# Altium schematic sheet pro.SchDoc
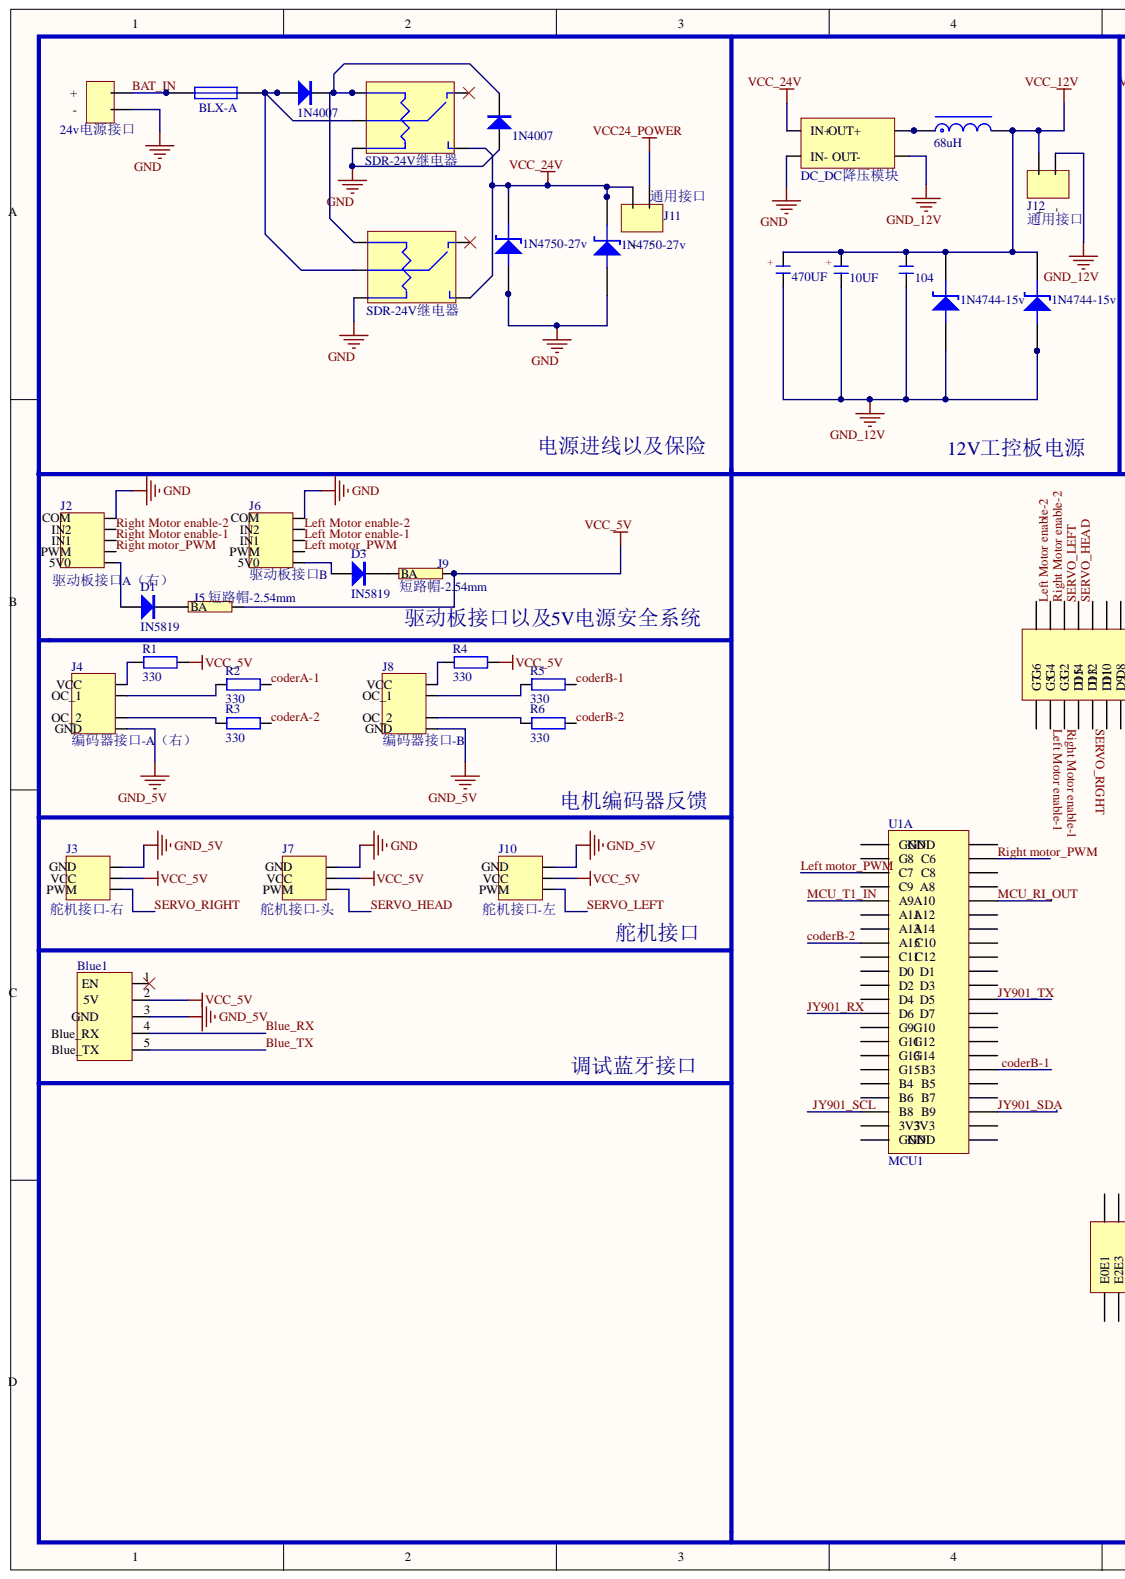
[diagram p0: pro.SchDoc, left]
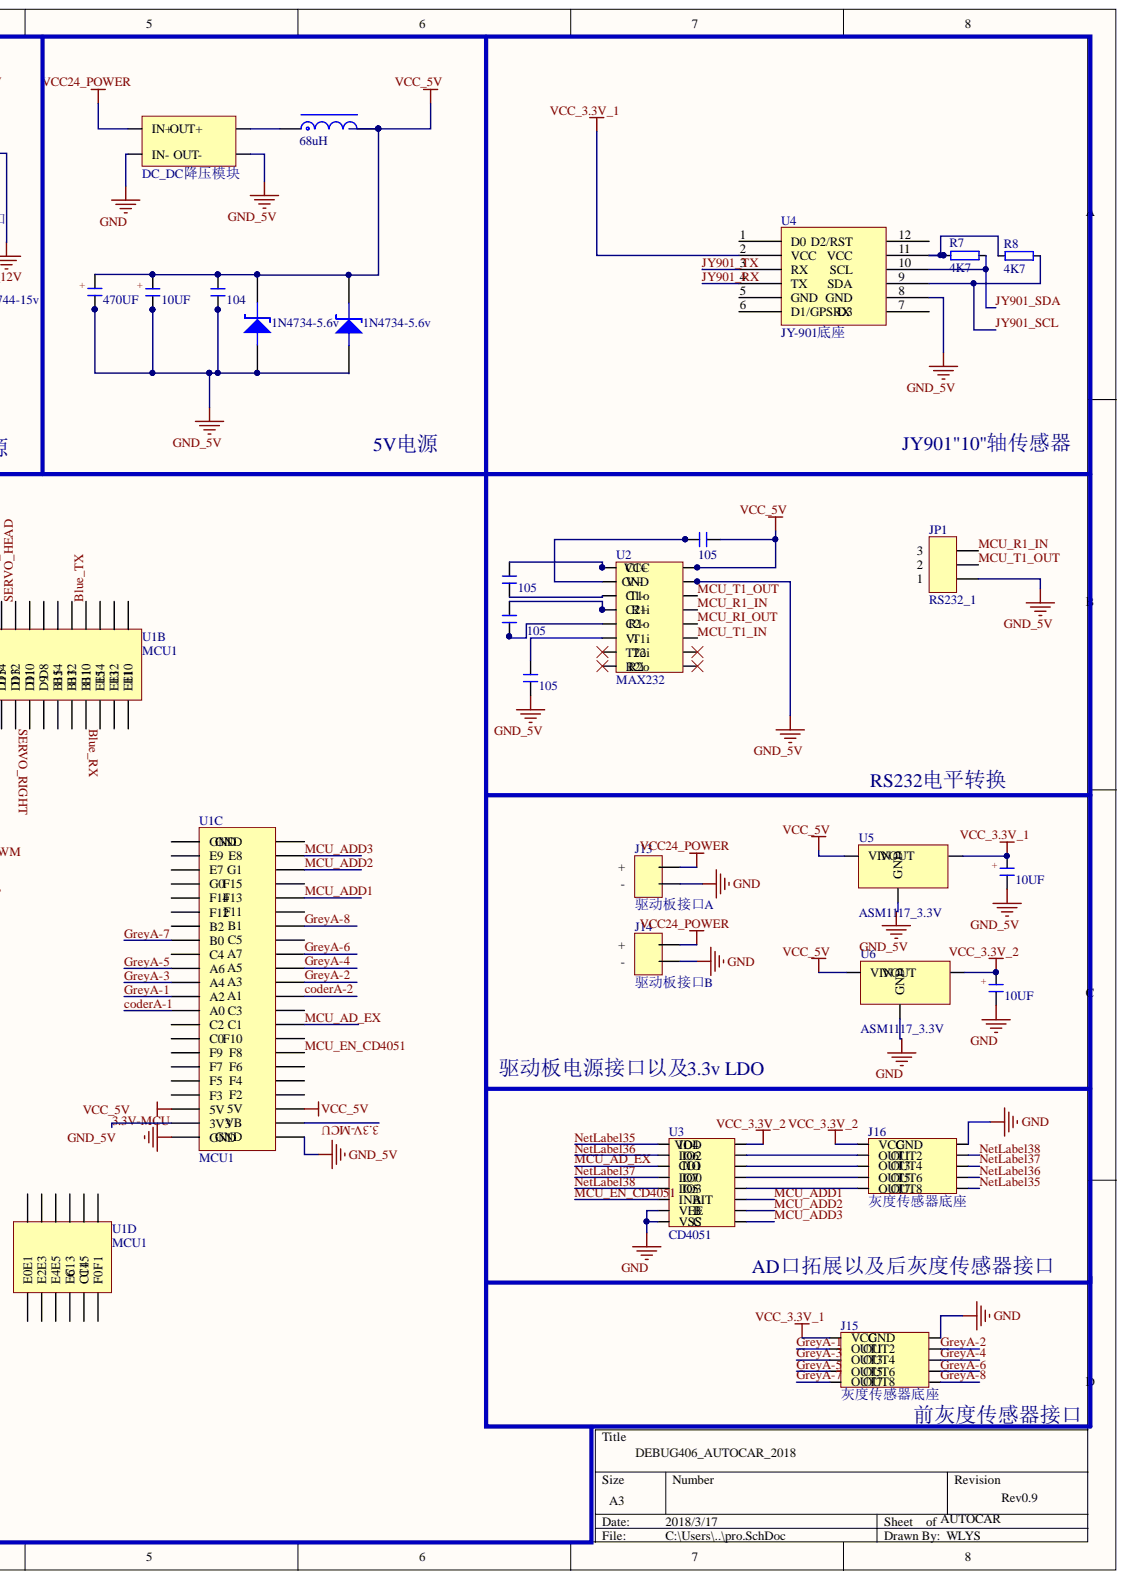
[diagram p1: pro.SchDoc, right]

## Parts
1  (no value)  [FU BLX-A]
Blue1  J？  [HDR2.54-LI-5P]  (value not drawn)
C1  470UF  [CAP10]
C2  10UF  [CAP6.3]
C3  104  [1206C]
C4  470UF  [CAP10]
C5  10UF  [CAP6.3]
C6  104  [1206C]
C7  105  [1206C]
C8  105  [1206C]
C9  105  [1206C]
C10  105  [1206C]
C11  10UF  [CAP6.3]
C12  10UF  [CAP6.3]
D1  IN5819  [DO-41]
D2  1N4007  [DO-41]
D3  IN5819  [DO-41]
D4  1N4007  [DO-41]
D5  1N4750-27v  [DO-41]
D6  1N4750-27v  [DO-41]
D7  1N4744-15v  [DO-41]
D8  1N4744-15v  [DO-41]
D9  1N4734-5.6v  [DO-41]
D10  1N4734-5.6v  [DO-41]
DC1  DC_DC降压模块  [DC_DC降压模块]
DC2  DC_DC降压模块  [DC_DC降压模块]
J1  (no value)  [autocar_battery]
J2  驱动板接口A（右）  [XH2.54-LI-5P]
J3  舵机接口-右  [XH2.54-LI-3P]
J4  编码器接口-A（右）  [XH2.54-LI-4P]
J5  短路帽-2.54mm  [HDR2.54-LI-2P]
J6  驱动板接口B  [XH2.54-LI-5P]
J7  舵机接口-头  [XH2.54-LI-3P]
J8  编码器接口-B  [XH2.54-LI-4P]
J9  短路帽-2.54mm  [HDR2.54-LI-2P]
J10  舵机接口-左  [XH2.54-LI-3P]
J11  通用接口  [通用接口]
J12  通用接口  [通用接口]
J13  驱动板接口A  [通用接口]
J14  驱动板接口B  [通用接口]
J15  灰度传感器底座  [DC-2.54-L-Z-10P]
J16  灰度传感器底座  [DC-2.54-L-Z-10P]
JP1  RS232_1  [XH2.54-LI-3P]
L1  68uH  [CDRH127]
L2  68uH  [CDRH127]
R1  330  [1206R]
R2  330  [1206R]
R3  330  [1206R]
R4  330  [1206R]
R5  330  [1206R]
R6  330  [1206R]
R7  4K7  [1206R]
R8  4K7  [1206R]
relay1  SDR-24V继电器  [SRD-C_B]
relay2  SDR-24V继电器  [SRD-C_B]
U1  MCU1  [核心板底座]  (parts A,B,C,D)
U2  MAX232  [SOP16_N]
U3  CD4051  [CD4051]
U4  JY-901底座  [JY901_过孔]
U5  ASM1117_3.3V  [ASM1117_3.3V]
U6  ASM1117_3.3V  [ASM1117_3.3V]

## Nets
GND: C11.2 D4.1 D5.1 D6.1 DC1.1(IN-_OUT-) DC2.1(IN-_OUT-) J1.1 J2.1 J6.1 J7.1 J13.2 J14.2 J15.9 J16.9 relay1.2 relay2.2 U3.7(VEE) U3.8(VSS) U6.1
GND_5V: Blue1.3 C4.2 C5.2 C6.2 C9.2 C12.2 D9.1 D10.1 DC2.3 J3.1 J4.4 J8.4 J10.1 JP1.1 U1.113(GND) U1.114 U2.15 U4.8 U5.1
GND_12V: C1.2 C2.2 C3.2 D7.1 D8.1 DC1.3 J12.2
VCC24_POWER: DC2.0(IN+) J11.2 J13.1 J14.1
VCC_3.3V_1: C12.1 J15.10(VCC) U4.2(VCC) U5.2
VCC_3.3V_2: C11.1 J16.10(VCC) U3.16 U6.2
VCC_5V: Blue1.2 C4.1 C5.1 C6.1 C10.2 D9.2 D10.2 J3.2 J5.2 J7.2 J9.2 J10.2 L2.2 R1.2 R4.2 U1.109(5V_5V) U1.110 U2.16 U5.3 U6.3
VCC_12V: C1.1 C2.1 C3.1 D7.2 D8.2 J12.1 L1.2
VCC_24V: D5.2 D6.2 DC1.0(IN+) J11.1 relay1.5 relay2.5
3.3V-MCU: U1.111(3V3) U1.112
BAT_IN: 1.1 J1.0
BLUE_RX: Blue1.4 U1.63(B11)
BLUE_TX: Blue1.5 U1.64
CODERA-1: R2.2 U1.95(A0_C3)
CODERA-2: R3.2 U1.94
CODERB-1: R5.2 U1.11
CODERB-2: R6.2 U1.30(A15)
GREYA-1: J15.8(OUT1) U1.93(A2_A1)
GREYA-2: J15.7 U1.92
GREYA-3: J15.6(OUT3) U1.91(A4_A3)
GREYA-4: J15.5 U1.90
GREYA-5: J15.4(OUT5) U1.89(A6_A5)
GREYA-6: J15.3 U1.88
GREYA-7: J15.2(OUT7) U1.85(B0_C5)
GREYA-8: J15.1 U1.84
JY901_RX: U1.20(D6) U4.4(TX)
JY901_SCL: R8.1 U1.6(B8) U4.9
JY901_SDA: R7.1 U1.5 U4.10
JY901_TX: U1.21 U4.3(RX)
LEFT MOTOR ENABLE-1: J6.3 U1.47(G5)
LEFT MOTOR ENABLE-2: J6.2 U1.48
LEFT MOTOR_PWM: J6.4 U1.40(C7)
MCU_AD_EX: U1.98 U3.3(CIO)
MCU_ADD1: U1.80 U3.11
MCU_ADD2: U1.76 U3.10
MCU_ADD3: U1.74 U3.9
MCU_EN_CD4051: U1.102 U3.6(INBIT)
MCU_R1_IN: JP1.3 U2.13
MCU_RI_OUT: U1.35 U2.12
MCU_T1_IN: U1.36(A9_A10) U2.11
MCU_T1_OUT: JP1.2 U2.14
NETLABEL35: J16.1 U3.1(VDD)
NETLABEL36: J16.3 U3.2(IO6)
NETLABEL37: J16.5 U3.4(IO7)
NETLABEL38: J16.7 U3.5(IO5)
RIGHT MOTOR ENABLE-1: J2.3 U1.49(G3)
RIGHT MOTOR ENABLE-2: J2.2 U1.50
RIGHT MOTOR_PWM: J2.4 U1.41
SERVO_HEAD: J7.3 U1.54
SERVO_LEFT: J10.3 U1.52
SERVO_RIGHT: J3.3 U1.53(D13)
Net1_2: 1.2 D2.1 relay1.3 relay2.3
NetC7_1: C7.1 U2.1(VCC)
NetC7_2: C7.2 U2.3(C1-)
NetC8_1: C8.1 U2.4(C2+)
NetC8_2: C8.2 U2.5(C2-)
NetC9_1: C9.1 U2.6(V-)
NetC10_1: C10.1 U2.2(GND)
NetD1_1: D1.1 J2.5
NetD1_2: D1.2 J5.1
NetD2_2: D2.2 D4.2 relay1.1 relay2.1
NetD3_1: D3.1 J6.5
NetD3_2: D3.2 J9.1
NetDC1_2: DC1.2 L1.1
NetDC2_2: DC2.2 L2.1
NetJ4_1: J4.1 R1.1
NetJ4_2: J4.2 R2.1
NetJ4_3: J4.3 R3.1
NetJ8_1: J8.1 R4.1
NetJ8_2: J8.2 R5.1
NetJ8_3: J8.3 R6.1
NetJ16_2: J16.2(OUT7) U3.12
NetJ16_4: J16.4(OUT5) U3.13
NetJ16_6: J16.6(OUT3) U3.14
NetJ16_8: J16.8(OUT1) U3.15
NetR7_2: R7.2 R8.2 U4.11

Not connected: Blue1 1; relay1 4; relay2 4; U1 1 2(GND) 3 4(3V3) 7 8(B6) 9 10(B4) 12(G15_B3) 13 14(G13) 15 16(G11) 17 18(G9_G10) 19 22(D4) 23 24(D2) 25 26(D0) 27 28(C11) 29 31 32(A13) 33 34(A11) 37 38(C9) 39 42(G8) 43 44(GND) 45(G7) 46 51(D15) 55(D11) 56 57(D9) 58 59(B15) 60 61(B13) 62 65(E15) 66 67(E13) 68 69(E11) 70 71(GND) 72 73(E9) 75(E7_G1) 77(G0) 78 79(F14) 81(F12) 82 83(B2_B1) 86 87(C4_A7) 96 97(C2_C1) 99(C0) 100 101(F9) 103(F7) 104 105(F5) 106 107(F3) 108 115 116(F0_F1) 117 118(C14) 119 120(E6) 121 122(E4_E5) 123 124(E2_E3) 125 126(E0_E1); U2 7(T2o) 8(R2i) 9 10; U4 1(D0_D2/RST) 5(GND) 6(D1/GPSRX) 7 12

## Library data of the parts
1 = 保险座: Component Kind: Standard; Library Name: Schlib_autocar.SchLib
Blue1 = BlueTooth_net: Component Kind: Standard; Library Name: Schlib_autocar.SchLib
C1 C4 = CAP_SMT_5: SMT 10mm; Component Kind: Standard; Library Name: 电容.IntLib
C2 C5 C11 C12 = CAP_SMT_3: SMT 6.3mm; Component Kind: Standard; Library Name: 电容.IntLib
C3 C6-C10 = CC_SMT_4: SMT1206; Component Kind: Standard; Library Name: 电容.IntLib
D1 D3 = IN5819: 1A-40V肖特基; Component Kind: Standard; Library Name: Schlib_autocar.SchLib
D2 D4 = 1N4007-DIP: Regulator; Component Kind: Standard; Library Name: 二极管.IntLib
D5-D10 = 稳压二极管: Component Kind: Standard; Library Name: Schlib_autocar.SchLib
  D5 D6: Description: 1N4750-27v
DC1 DC2 = 5A_DC_DC降压模块: Component Kind: Standard; Library Name: Schlib_autocar.SchLib
J1 = autocar_battery: 智能车24v电池连接端口; Component Kind: Standard; Library Name: Schlib_autocar.SchLib
J2 J6 = 驱动板接口: Component Kind: Standard; Library Name: Schlib_autocar.SchLib
J3 J7 J10 = 舵机接口: Component Kind: Standard; Library Name: Schlib_autocar.SchLib
J4 J8 = 编码器接口: Component Kind: Standard; Library Name: Schlib_autocar.SchLib
J13 J14 = 通用接口: Component Kind: Standard; Library Name: Schlib_autocar.SchLib
JP1 = XH2.54_3Pins-DIP: White Small; Component Kind: Standard; Library Name: 接口.IntLib
L1 L2 = PowerShielded-L-SMT: PowerShieldedInductorSet; Component Kind: Standard; Library Name: 电感.IntLib
R1-R6 = 电阻-1206: 1206; Component Kind: Standard; Library Name: Schlib_autocar.SchLib
R7 R8 = SMT1206: SMT_1206; Component Kind: Standard; Library Name: 电阻.IntLib
U1 = 核心板插座: Component Kind: Standard; Library Name: Schlib_autocar.SchLib
U2 = MAX3232: Component Kind: Standard; Library Name: Schlib_autocar.SchLib
U4 = JY-901底座: 高精度9轴传感器; Component Kind: Standard; Library Name: Schlib_autocar.SchLib
J5 J9 = 短路帽-2.54mm: Component Kind: Standard; Library Name: Schlib_autocar.SchLib
J11 J12 = 通用接口: Component Kind: Standard; Library Name: Schlib_autocar.SchLib
J15 J16 = 灰度传感器底座: Component Kind: Standard; Library Name: Schlib_autocar.SchLib
relay1 relay2 = SDR-24V继电器: Component Kind: Standard; Library Name: Schlib_autocar.SchLib
U3 = CD4051: Component Kind: Standard; Library Name: Schlib_autocar.SchLib
U5 U6 = ASM1117_3.3V: Component Kind: Standard; Library Name: Schlib_autocar.SchLib
